# Altium schematic sheet Main.SchDoc
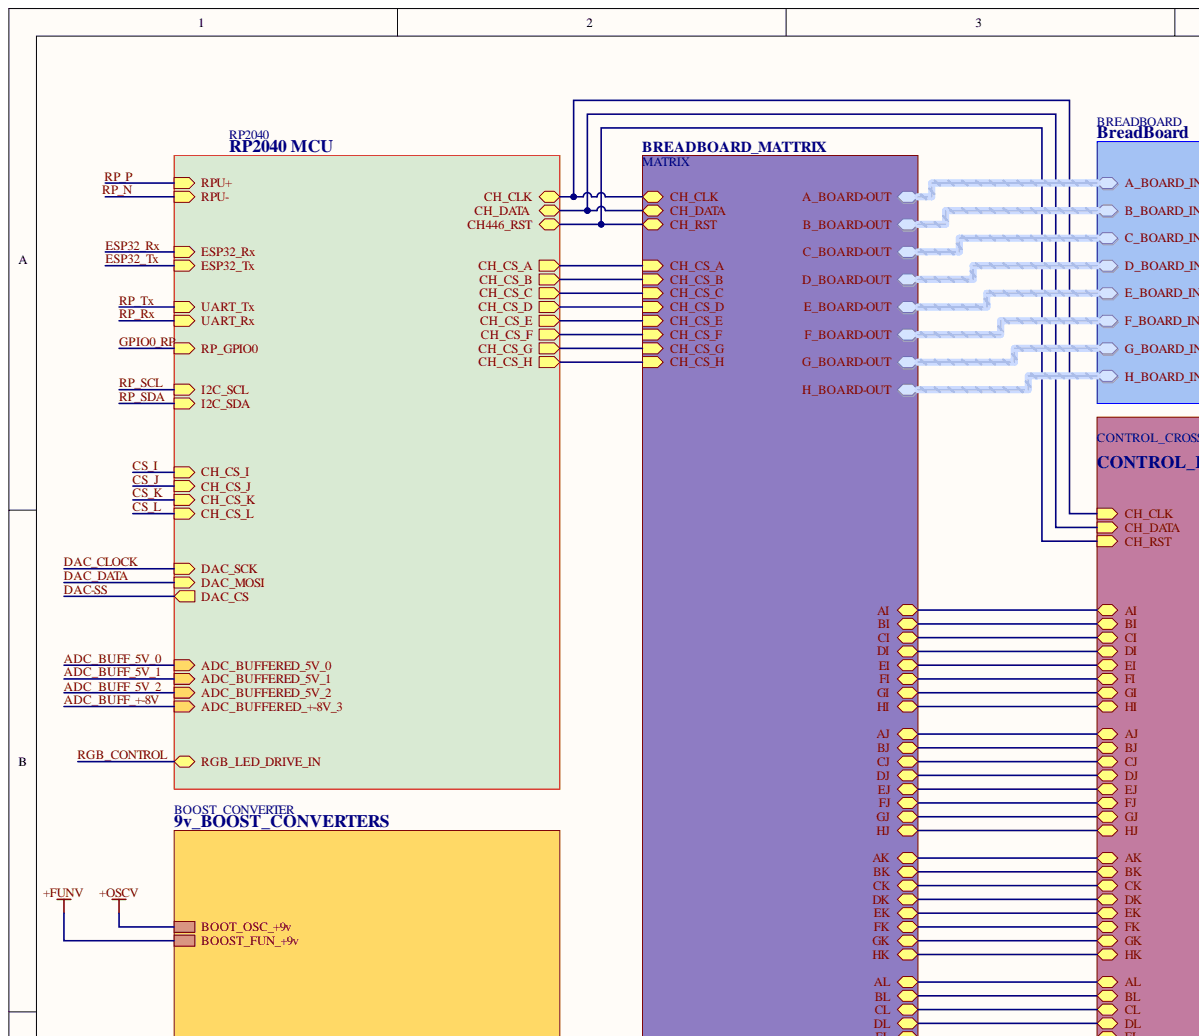
[diagram p0: Main.SchDoc, top left]
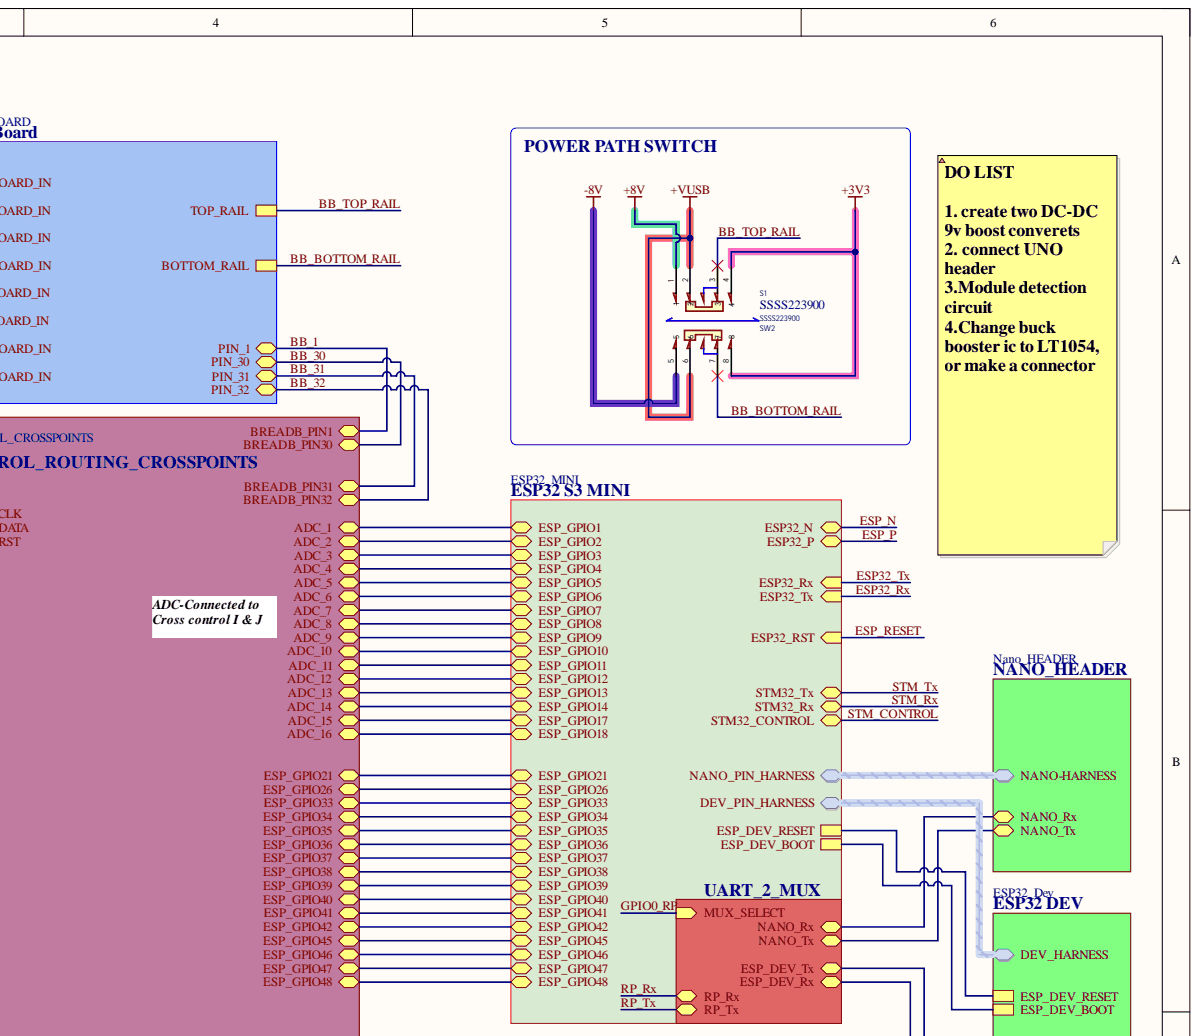
[diagram p1: Main.SchDoc, top right]
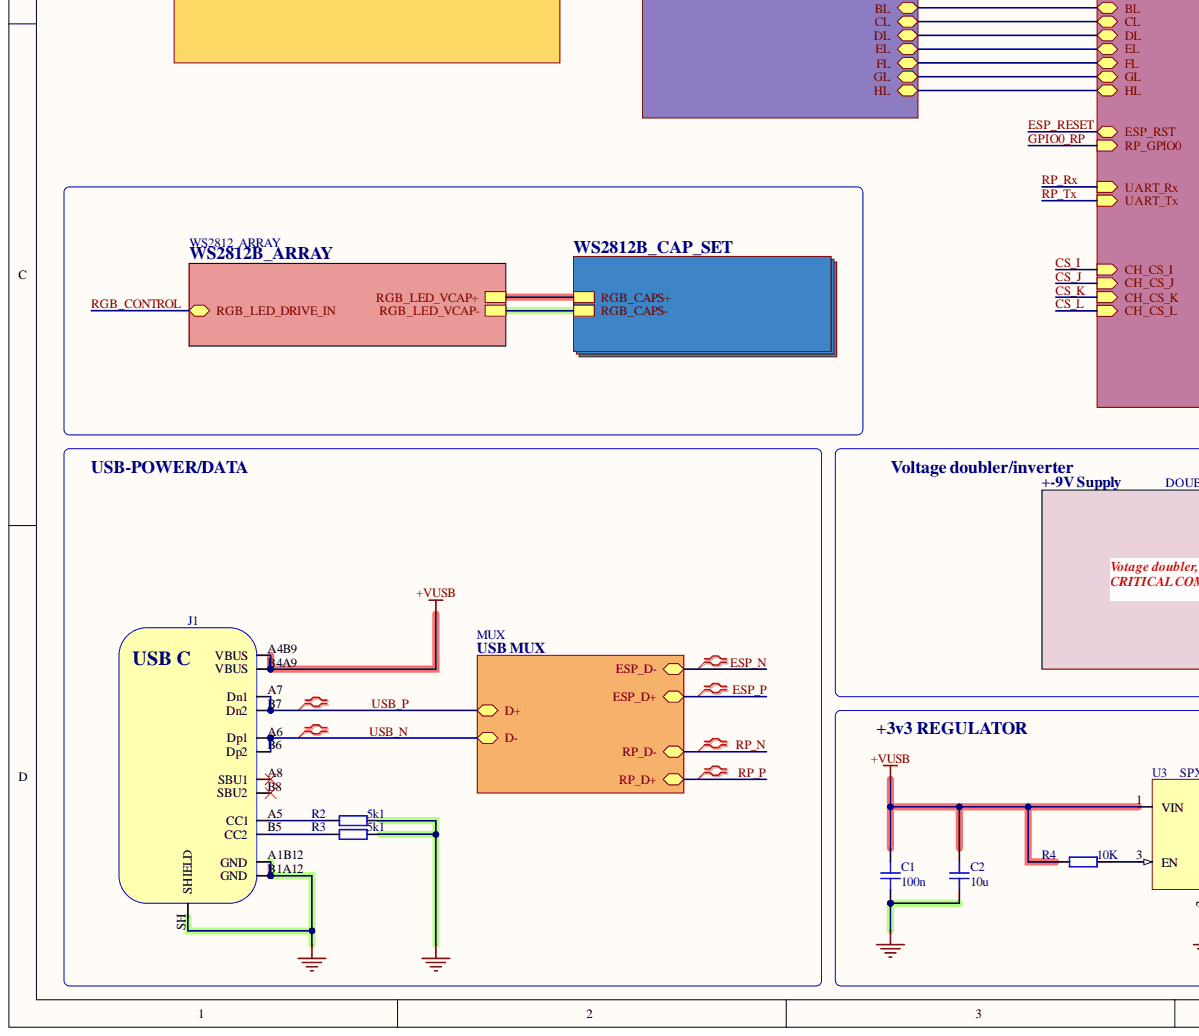
[diagram p2: Main.SchDoc, bottom left]
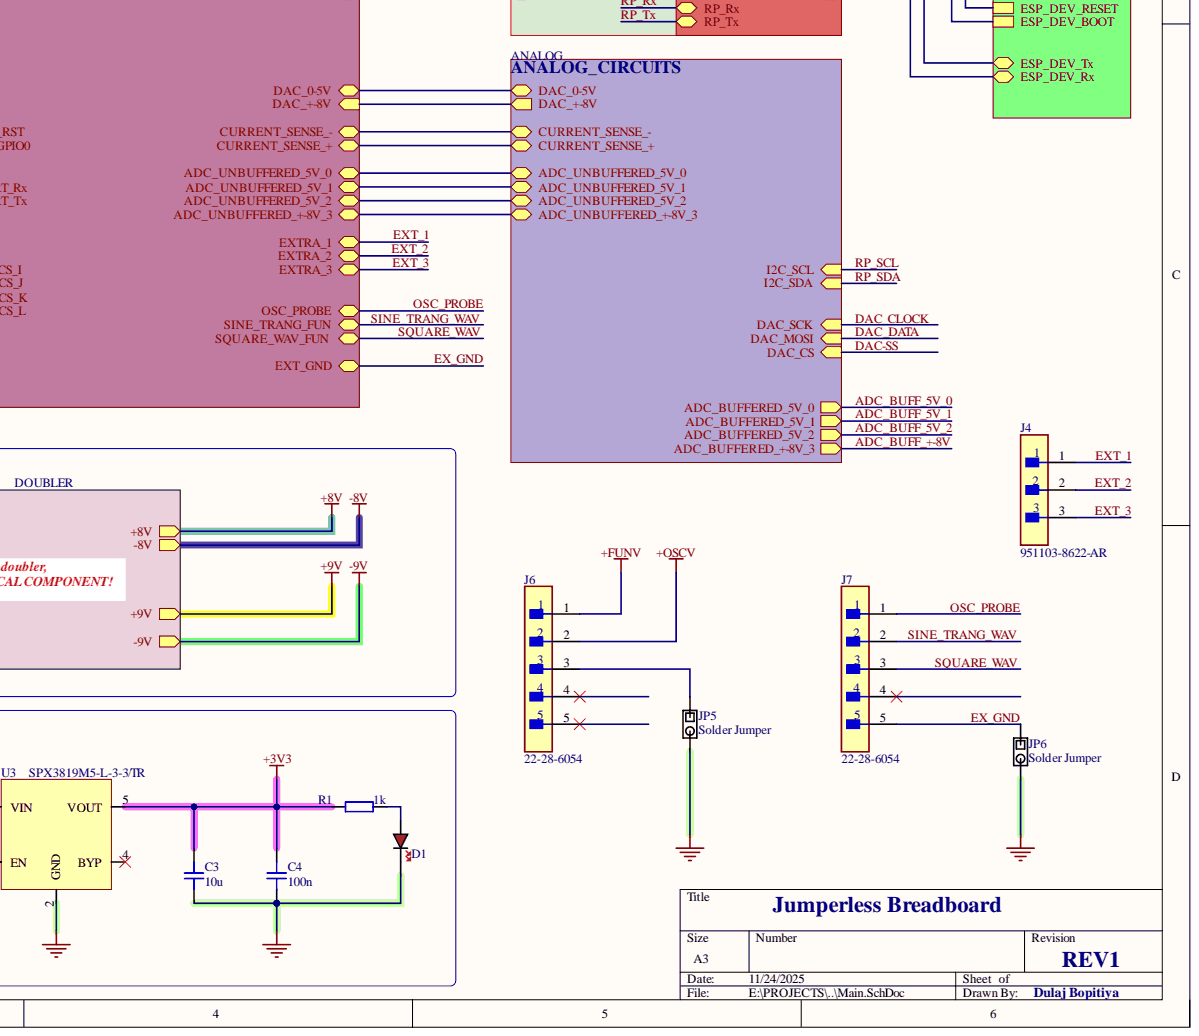
[diagram p3: Main.SchDoc, bottom right]

## Parts
C1  100n  [CAP_0805]
C2  10u  [CAP_0805]
C3  10u  [CAP_0805]
C4  100n  [CAP_0805]
D1  RED  [LED_0603_RED]  (value not drawn)
J1  B4105-GF-A-060  [USB4105-GF-A-060]  (value not drawn)
J4  951103-8622-AR  [FP-951103-8622-AR-MFG]
J6  22-28-6054  [FP-22-28-6054-MFG]
J7  22-28-6054  [FP-22-28-6054-MFG]
JP5  Solder Jumper  [SolderJumper_Single]
JP6  Solder Jumper  [SolderJumper_Single]
R1  1k  [RES_0603]
R2  5k1  [RES_0603]
R3  5k1  [RES_0603]
R4  10K  [RES_0603]
S1  SSSS223900  Reference: SW2  [easyeda2kicad:SW-TH_SSSS223900]
U3  SPX3819M5-L-3-3/TR  [SOT-23-5]

## Nets
+3V3: C3.2 C4.2 R1.1 S1.4 S1.8 U3.5(VOUT)
+8V: S1.1
+FUNV: J6.1
+OSCV: J6.2
+VUSB: C1.2 C2.2 J1.A4B9(VBUS) J1.B4A9(VBUS) R4.1 S1.2 S1.6 U3.1(VIN)
-8V: S1.5
GND: C1.1 C2.1 C3.1 C4.1 D1.K J1.A1B12(GND) J1.B1A12(GND) J1.SH(SHIELD) JP5.2 JP6.2 R2.2 R3.2 U3.2(GND)
BB_BOTTOM_RAIL_1: S1.7  [also labelled BB_BOTTOM_RAIL]
BB_TOP_RAIL_1: S1.3  [also labelled BB_TOP_RAIL]
EX_GND_1: J7.5 JP6.1  [also labelled EX_GND]
EXT_1_1: J4.1  [also labelled EXT_1]
EXT_2_1: J4.2  [also labelled EXT_2]
EXT_3_1: J4.3  [also labelled EXT_3]
OSC_PROBE_1: J7.1  [also labelled OSC_PROBE]
SINE_TRANG_WAV_1: J7.2  [also labelled SINE_TRANG_WAV]
SQUARE_WAV_1: J7.3  [also labelled SQUARE_WAV]
USB_1_N: J1.A6(Dp1) J1.B6(Dp2)  [also labelled USB_N]
USB_1_P: J1.A7(Dn1) J1.B7(Dn2)  [also labelled USB_P]
NetD1_A: D1.A R1.2
NetJ1_A5: J1.A5(CC1) R2.1
NetJ1_A8: J1.A8(SBU1)
NetJ1_B5: J1.B5(CC2) R3.1
NetJ1_B8: J1.B8(SBU2)
NetJ6_3: J6.3 JP5.1
NetJ6_4: J6.4
NetJ6_5: J6.5
NetJ7_4: J7.4
NetR4_2: R4.2 U3.3(EN)
NetU3_4: U3.4(BYP)
+9V:
-9V:
A_BOARD-OUT.AB_B2_1:
A_BOARD-OUT.AB_B3_1:
A_BOARD-OUT.AB_B4_1:
A_BOARD-OUT.AB_B5_1:
A_BOARD-OUT.AB_B6_1:
A_BOARD-OUT.AB_B7_1:
A_BOARD-OUT.AB_B8_1:
ADC_1_1:
ADC_2_1:
ADC_3_1:
ADC_4_1:
ADC_5_1:
ADC_6_1:
ADC_7_1:
ADC_8_1:
ADC_9_1:
ADC_10_1:
ADC_11_1:
ADC_12_1:
ADC_13_1:
ADC_14_1:
ADC_15_1:
ADC_16_1:
ADC_BUFF_5V_0_1:  [also labelled ADC_BUFF_5V_0]
ADC_BUFF_5V_1_1:  [also labelled ADC_BUFF_5V_1]
ADC_BUFF_5V_2_1:  [also labelled ADC_BUFF_5V_2]
ADC_BUFF_+-8V_1:  [also labelled ADC_BUFF_+-8V]
ADC_UNBUFFERED_5V_0_1:
ADC_UNBUFFERED_5V_1_1:
ADC_UNBUFFERED_5V_2_1:
ADC_UNBUFFERED_+-8V_3_1:
AI_1:
AJ_1:
AK_1:
AL_1:
B_BOARD-OUT.BB_B9_1:
B_BOARD-OUT.BB_B10_1:
B_BOARD-OUT.BB_B11_1:
B_BOARD-OUT.BB_B12_1:
B_BOARD-OUT.BB_B13_1:
B_BOARD-OUT.BB_B14_1:
B_BOARD-OUT.BB_B15_1:
BB_1_1:  [also labelled BB_1]
BB_30_1:  [also labelled BB_30]
BB_31_1:  [also labelled BB_31]
BB_32_1:  [also labelled BB_32]
BI_1:
BJ_1:
BK_1:
BL_1:
C_BOARD-OUT.CB_B16_1:
C_BOARD-OUT.CB_B17_1:
C_BOARD-OUT.CB_B18_1:
C_BOARD-OUT.CB_B19_1:
C_BOARD-OUT.CB_B20_1:
C_BOARD-OUT.CB_B21_1:
C_BOARD-OUT.CB_B22_1:
CH446_RST_1:
CH_CLK_1:
CH_CS_A_1:
CH_CS_B_1:
CH_CS_C_1:
CH_CS_D_1:
CH_CS_E_1:
CH_CS_F_1:
CH_CS_G_1:
CH_CS_H_1:
CH_DATA_1:
CI_1:
CJ_1:
CK_1:
CL_1:
CS_I_1:  [also labelled CS_I]
CS_J_1:  [also labelled CS_J]
CS_K_1:  [also labelled CS_K]
CS_L_1:  [also labelled CS_L]
CURRENT_SENSE_+_1:
CURRENT_SENSE_-_1:
D_BOARD-OUT.DB_B23_1:
D_BOARD-OUT.DB_B24_1:
D_BOARD-OUT.DB_B25_1:
D_BOARD-OUT.DB_B26_1:
D_BOARD-OUT.DB_B27_1:
D_BOARD-OUT.DB_B28_1:
D_BOARD-OUT.DB_B29_1:
DAC-SS_1:  [also labelled DAC-SS]
DAC_0-5V_1:
DAC_+-8V_1:
DAC_CLOCK_1:  [also labelled DAC_CLOCK]
DAC_DATA_1:  [also labelled DAC_DATA]
DEV_HARNESS.DEV_IO02_1:
DEV_HARNESS.DEV_IO04_1:
DEV_HARNESS.DEV_IO05_1:
DEV_HARNESS.DEV_IO06_1:
DEV_HARNESS.DEV_IO07_1:
DEV_HARNESS.DEV_IO08_1:
DEV_HARNESS.DEV_IO09_1:
DEV_HARNESS.DEV_IO10_1:
DEV_HARNESS.DEV_IO11_1:
DEV_HARNESS.DEV_IO12_1:
DEV_HARNESS.DEV_IO13_1:
DEV_HARNESS.DEV_IO14_1:
DEV_HARNESS.DEV_IO15_1:
DEV_HARNESS.DEV_IO16_1:
DEV_HARNESS.DEV_IO17_1:
DEV_HARNESS.DEV_IO18_1:
DEV_HARNESS.DEV_IO19_1:
DEV_HARNESS.DEV_IO21_1:
DEV_HARNESS.DEV_IO22_1:
DEV_HARNESS.DEV_IO23_1:
DEV_HARNESS.DEV_IO25_1:
DEV_HARNESS.DEV_IO26_1:
DEV_HARNESS.DEV_IO27_1:
DEV_HARNESS.DEV_IO32_1:
DEV_HARNESS.DEV_IO33_1:
DEV_HARNESS.DEV_IO34_1:
DEV_HARNESS.DEV_IO35_1:
DEV_HARNESS.DEV_IO36_1:
DEV_HARNESS.DEV_IO39_1:
DEV_HARNESS.DEV_RESET_1:
DI_1:
DJ_1:
DK_1:
DL_1:
E_BOARD-OUT.EB_B33_1:
E_BOARD-OUT.EB_B34_1:
E_BOARD-OUT.EB_B35_1:
E_BOARD-OUT.EB_B36_1:
E_BOARD-OUT.EB_B37_1:
E_BOARD-OUT.EB_B38_1:
E_BOARD-OUT.EB_B39_1:
EI_1:
EJ_1:
EK_1:
EL_1:
ESP32_Rx_1:  [also labelled ESP32_Rx]
ESP32_Tx_1:  [also labelled ESP32_Tx]
ESP_1_N:  [also labelled ESP_N]
ESP_1_P:  [also labelled ESP_P]
ESP_DEV_BOOT_1:
ESP_DEV_RESET_1:
ESP_DEV_Rx_1:
ESP_DEV_Tx_1:
ESP_GPIO21_1:
ESP_GPIO26_1:
ESP_GPIO33_1:
ESP_GPIO34_1:
ESP_GPIO35_1:
ESP_GPIO36_1:
ESP_GPIO37_1:
ESP_GPIO38_1:
ESP_GPIO39_1:
ESP_GPIO40_1:
ESP_GPIO41_1:
ESP_GPIO42_1:
ESP_GPIO45_1:
ESP_GPIO46_1:
ESP_GPIO47_1:
ESP_GPIO48_1:
ESP_RESET_1:  [also labelled ESP_RESET]
F_BOARD-OUT.EF_B46_1:
F_BOARD-OUT.FB_B40_1:
F_BOARD-OUT.FB_B41_1:
F_BOARD-OUT.FB_B42_1:
F_BOARD-OUT.FB_B43_1:
F_BOARD-OUT.FB_B44_1:
F_BOARD-OUT.FB_B45_1:
FI_1:
FJ_1:
FK_1:
FL_1:
G_BOARD-OUT.GB_B47_1:
G_BOARD-OUT.GB_B48_1:
G_BOARD-OUT.GB_B49_1:
G_BOARD-OUT.GB_B50_1:
G_BOARD-OUT.GB_B51_1:
G_BOARD-OUT.GB_B52_1:
G_BOARD-OUT.GF_B53_1:
GI_1:
GJ_1:
GK_1:
GL_1:
GPIO0_RP_1:  [also labelled GPIO0_RP]
H_BOARD-OUT.HB_B54_1:
H_BOARD-OUT.HB_B55_1:
H_BOARD-OUT.HB_B56_1:
H_BOARD-OUT.HB_B57_1:
H_BOARD-OUT.HB_B58_1:
H_BOARD-OUT.HB_B59_1:
H_BOARD-OUT.HF_B60_1:
HI_1:
HJ_1:
HK_1:
HL_1:
NANO-HARNESS.NANO_A0_1:
NANO-HARNESS.NANO_A1_1:
NANO-HARNESS.NANO_A2_1:
NANO-HARNESS.NANO_A3_1:
NANO-HARNESS.NANO_A4_1:
NANO-HARNESS.NANO_A5_1:
NANO-HARNESS.NANO_A6_1:
NANO-HARNESS.NANO_A7_1:
NANO-HARNESS.NANO_AREF_1:
NANO-HARNESS.NANO_D0_1:
NANO-HARNESS.NANO_D1_1:
NANO-HARNESS.NANO_D2_1:
NANO-HARNESS.NANO_D3_1:
NANO-HARNESS.NANO_D4_1:
NANO-HARNESS.NANO_D5_1:
NANO-HARNESS.NANO_D6_1:
NANO-HARNESS.NANO_D7_1:
NANO-HARNESS.NANO_D8_1:
NANO-HARNESS.NANO_D9_1:
NANO-HARNESS.NANO_D10_1:
NANO-HARNESS.NANO_D11_1:
NANO-HARNESS.NANO_D12_1:
NANO-HARNESS.NANO_D13_1:
NANO-HARNESS.NANO_RESET_1:
NANO_Rx_1:
NANO_Tx_1:
RGB_CAPS+_1:
RGB_CAPS-_1:
RGB_CONTROL_1:  [also labelled RGB_CONTROL]
RP_1_N:  [also labelled RP_N]
RP_1_P:  [also labelled RP_P]
RP_Rx_1:  [also labelled RP_Rx]
RP_SCL_1:  [also labelled RP_SCL]
RP_SDA_1:  [also labelled RP_SDA]
RP_Tx_1:  [also labelled RP_Tx]
STM_CONTROL_1:  [also labelled STM_CONTROL]
STM_Rx_1:  [also labelled STM_Rx]
STM_Tx_1:  [also labelled STM_Tx]

## Library data of the parts
C1-C4 = CAP_0805: Capacitor SMD 0805; Component Kind: Standard; Library Name: Schematic-Lib-PhilsLab.SchLib
D1 = LED_0603_RED: LED 0603 RED SMD; Component Kind: Standard; Library Name: Schematic-Lib-PhilsLab.SchLib
J1 = USB4105-GF-A-060: USB Connector Type C SMT 12 Pin; Manufacturer: GCT; Component Kind: Standard; Distributor Link: https://eu.mouser.com/ProductDetail/GCT/USB4105-GF-A-060?qs=QNEnbhJQKvY2mocwNJzgfA%3D%3D; Manufacturer Part Number: USB4105-GF-A-060; Library Name: Schematic-Lib-PhilsLab.SchLib
J4 = CMP-05379-000003-1: CONN HEADER VERT 3POS 2MM; Manufacturer: 3M; Conn Gender: Male; Conn Orientation: Straight; Current Rating: 2A; Number Of Contacts: 3; Automotive: No; Category: Conn; Component Kind: Standard; ComponentLink2Description: Datasheet; ComponentLink2URL: http://multimedia.3m.com/mws/media/1400506O/3m-pin-strip-header-951-series-78-5100-2653-3.pdf; Contact Material: Gold,Nickel; Device Class L1: Connectors; Device Class L2: Headers and Wire Housings; Device Class L3: unset; Digikey Description: CONN HEADER VERT 3POS 2MM; Height: 6.3mm; Lead Free: Yes; Library Name: Altium Content Vault; Manufacturer Part Number: 951103-8622-AR; Mouser Description: Header; PinStrip; CopperAlloy; HighTempThermoplastic; 3Cnts; 1A; RtAngle; 951Series; Number Of Rows: 1; Octopart: https://octopart.com/951103-8622-ar-3m-14400669; Package: 951103-8622-AR; Pitch: 2mm; RoHS: TRUE; Temperature: -55°C to +125°C; Temperature Range High: +125°C; Temperature Range Low: -55°C
J6 J7 = CMP-04776-001058-1: KK 100 HDR ASSY RA BKWY 05 CKT 3; Contact Resistance: 20mR; Current Rating: 4A; Gender: Male; Glow Wire Compliant: Non-compliant; Number of Circuits: 5; Pitch: 2.54mm; Voltage Rating: 250V; Component Kind: Standard; Contact Plating: Gold; ELV: Compliant; Flammability Rating: UL94 V-0; Library Name: Altium Content Vault; Max Operating Temperature: 75°C; Min Operating Temperature: 0°C; Mount: Through Hole; Number of Contacts: 5; Number of Positions: 5; Number of Rows: 1; Orientation: Right Angle; Package Quantity: 1500; Packaging: Bulk; RoHS Compliant: Yes; Series: 42376; Termination: Solder
JP5 JP6 = SolderJumper_Single: Solder Jumper Single 0.5mm Pitch; Component Kind: Standard; Library Name: Schematic-Lib-PhilsLab.SchLib
R1-R4 = RES_0603: Resistor SMD 0603; Component Kind: Standard; Library Name: Schematic-Lib-PhilsLab.SchLib
U3 = SPX3819M5-L-3-3/TR: 500mA +3.3V Low-Noise LDO Voltage Regulator; Manufacturer: MaxLinear; Component Kind: Standard; Manufacturer Part Number: SPX3819M5-L-3-3/TR; Library Name: Schematic-Lib-PhilsLab.SchLib
S1 = SSSS223900: Component Kind: Standard; Datasheet: https://lcsc.com/product-detail/Toggle-Switches_ALPS_SSSS223900_SSSS223900_C160874.html; LCSC Part: C160874; Library Name: ssss223900.SchLib; Value: SSSS223900
